# Altium schematic sheet 5V UPS.SchDoc
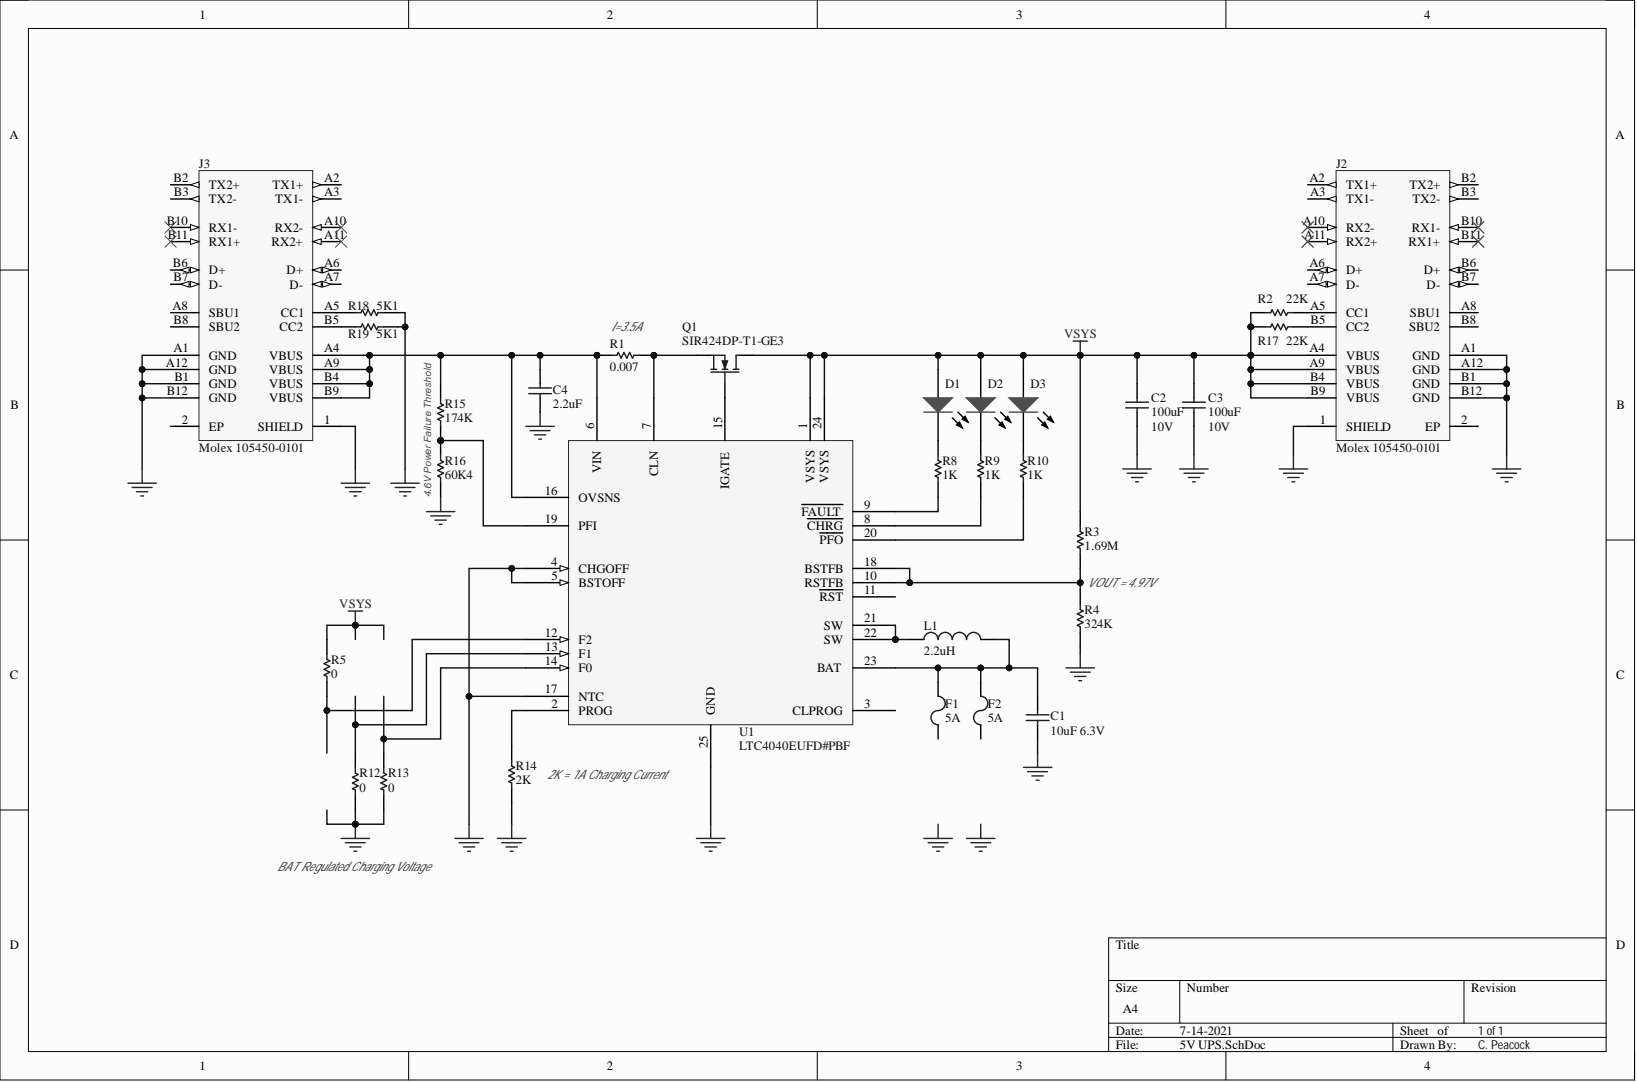
[diagram p0: 5V UPS.SchDoc]

## Parts
C1  10uF 6.3V  [PCB-jc9lkjqzzknv4ogtkgcu-1]
C2  100uF  Voltage Rating (DC): 10V  [CAPC3225X280X60ML40T30]
C3  100uF  Voltage Rating (DC): 10V  [CAPC3225X280X60ML40T30]
C4  2.2uF  [CAPC2013X145X50ML20T25]
D1  Lite-On LTST-C170KRKT  [PCB-5ta9mte2am12bu12jwvi-1]  (value not drawn)
D2  Lite-On LTST-C170KRKT  [PCB-5ta9mte2am12bu12jwvi-1]  (value not drawn)
D3  Lite-On LTST-C170KRKT  [PCB-5ta9mte2am12bu12jwvi-1]  (value not drawn)
F1  5A  [RESC3216X70X50ML20T25]
F2  5A  [RESC3216X70X50ML20T25]
J2  Molex 105450-0101  Manufacturer Part Number: 105450-0101  [PCB-zb4thd4mh8xiiqufiqw2-1]
J3  Molex 105450-0101  Manufacturer Part Number: 105450-0101  [PCB-zb4thd4mh8xiiqufiqw2-1]
L1  2.2uH  [PCB-eh2uh0b1ckxc83nsi4qq-1]
Q1  SIR424DP-T1-GE3  [PCB-12911244-1]
R1  0.007  [PCB-19418829-1]
R2  22K  [RESC2013X60X35ML10T20]
R3  1.69M  [RESC2013X60X35ML10T20]
R4  324K  [RESC2013X60X35ML10T20]
R5  0  [RESC2013X60X35ML10T20]
R8  1K  [RESC2013X60X35ML10T20]
R9  1K  [RESC2013X60X35ML10T20]
R10  1K  [RESC2013X60X35ML10T20]
R12  0  [RESC2013X60X35ML10T20]
R13  0  [RESC2013X60X35ML10T20]
R14  2K  [RESC2013X60X35ML10T20]
R15  174K  [RESC2013X60X35ML10T20]
R16  60K4  [RESC2013X60X35ML10T20]
R17  22K  [RESC2013X60X35ML10T20]
R18  5K1  [RESC2013X60X35ML10T20]
R19  5K1  [RESC2013X60X35ML10T20]
U1  LTC4040EUFD#PBF  [LT-UFD-24_M]

## Nets
GND: C1.1 C2.1 C3.1 C4.1 J2.1(SHIELD) J2.A1(GND) J2.A12(GND) J2.B1(GND) J2.B12(GND) J3.1(SHIELD) J3.A1(GND) J3.A12(GND) J3.B1(GND) J3.B12(GND) R4.2 R12.1 R13.1 R14.1 R16.1 R18.2 R19.2 U1.4(CHGOFF) U1.5(BSTOFF) U1.17(NTC) U1.25(GND)
VSYS: C2.2 C3.2 D1.2 D2.2 D3.2 J2.A4(VBUS) J2.A9(VBUS) J2.B4(VBUS) J2.B9(VBUS) Q1.D R2.1 R3.2 R5.2 R17.1 U1.1(VSYS) U1.24(VSYS)
NetC1_2: C1.2 F1.2 F2.2 L1.2 U1.23(BAT)
NetC4_2: C4.2 J3.A4(VBUS) J3.A9(VBUS) J3.B4(VBUS) J3.B9(VBUS) R1.1 R15.2 U1.6(VIN) U1.16(OVSNS)
NetD1_1: D1.1 R8.2
NetD2_1: D2.1 R9.2
NetD3_1: D3.1 R10.2
NetJ2_A5: J2.A5(CC1) R2.2
NetJ2_B5: J2.B5(CC2) R17.2
NetJ3_A5: J3.A5(CC1) R18.1
NetJ3_B5: J3.B5(CC2) R19.1
NetL1_1: L1.1 U1.21(SW) U1.22(SW)
NetQ1_G: Q1.G U1.15(IGATE)
NetQ1_S: Q1.S R1.2 U1.7(CLN)
NetR3_1: R3.1 R4.1 U1.10(RSTFB) U1.18(BSTFB)
NetR5_1: R5.1 U1.12(F2)
NetR8_1: R8.1 U1.9(FAULT)
NetR9_1: R9.1 U1.8(CHRG)
NetR10_1: R10.1 U1.20(PFO)
NetR12_2: R12.2 U1.13(F1)
NetR13_2: R13.2 U1.14(F0)
NetR14_2: R14.2 U1.2(PROG)
NetR15_1: R15.1 R16.2 U1.19(PFI)

Not connected: F1 1; F2 1; J2 2(EP) A2(TX1+) A3(TX1-) A6(D+) A7(D-) A8(SBU1) A10(RX2-) A11(RX2+) B2(TX2+) B3(TX2-) B6(D+) B7(D-) B8(SBU2) B10(RX1-) B11(RX1+); J3 2(EP) A2(TX1+) A3(TX1-) A6(D+) A7(D-) A8(SBU1) A10(RX2-) A11(RX2+) B2(TX2+) B3(TX2-) B6(D+) B7(D-) B8(SBU2) B10(RX1-) B11(RX1+); U1 3(CLPROG) 11(RST)

## Library data of the parts
C1 = CMP-e7812e33bfa43846-1: Cap Ceramic 10uF 6.3V X7R 10% SMD 0805 125°C Embossed T/R; Manufacturer Part Number: JMK212B7106KG-T; Capacitance: 10u; Capacitance Tolerance: ±10%; Case/Package: 0805, 2012; Component Kind: Standard; Dielectric Characteristic: X7R; Digikey: 587-2396-1-ND; Library Name: CommunityVault; Lifecycle Status: Not Listed by Manufacturer; Mounting Style: Surface Mount; Number of Pins: 2; Octopart URL: http://octopart.com/jmk212b7106kg-t-taiyo+yuden-12090691; OctopartID: e7812e33bfa43846; Packaging: Cut Tape (CT); RoHS: Compliant; Voltage Rating (DC): 6.3 V
C2 C3 = CMP-9010136-2: Samsung Electro-Mechanics 100F 20% 10 V X5R Dielectric SMD Ceramic Multilayer Capacitor 1210; Manufacturer Part Number: CL32A107MPVNNNE; Capacitance: 0.0001 F; Lead-Free Status: Lead Free; Capacitance Tolerance: ±20%; Case/Package: 1210; Component Kind: Standard; Dielectric Characteristic: X5R; Library Name: CommunityVault; Mounting Style: Surface Mount; Octopart Url: https://octopart.com/cl32a107mpvnnne-samsung-24905709; OctopartID: 639fabe94114bc58; RoHS: Compliant
C4 = CMP-7e2cb7ab90b4a1eb-1: 0805 2.2 uF 25 V ±10 % Tolerance X5R SMT Multilayer Ceramic Capacitor; Manufacturer Part Number: CC0805KRX5R8BB225; Capacitance: 2.20 µF; Capacitance Tolerance: ±10%; Lead-Free Status: Lead Free; Voltage Rating (DC): 25.0 V; Case/Package: 0805; Component Kind: Standard; Dielectric Characteristic: X5R; Halogen Free Status: Halogen Free; Library Name: CommunityVault; Mounting Style: Surface Mount; Octopart URL: https://octopart.com/cc0805krx5r8bb225-yageo-9292798; OctopartID: 7e2cb7ab90b4a1eb; Packaging: Tape & Reel (TR); RoHS: Compliant
D1-D3 = CMP-1544870-4: Red 2 x 1.25 x 1.1 mm 130° Water Clear 54 mcd 2 V LED Surface Mount; Case/Package (SI): 2012; Forward Voltage: 2.00 V; Lead-Free Status: Lead Free; Lens Type: Non-Diffused; Luminous Intensity: 54.0 mcd; Wavelength: 639 nm; Case/Package: 0805; Color: Red; Component Kind: Standard; Library Name: CommunityVault; Manufacturer Part Number: LTST-C170KRKT; Mounting Style: Surface Mount, Vertical; Octopart URL: http://octopart.com/ltst-c170krkt-lite-on-549878; OctopartID: 1d24d4265bb4c2e3; Packaging: Cut Tape (CT), Tape & Reel (TR); RoHS: Compliant; Size-Height: 1.10 mm; Size-Length: 2.00 mm; Size-Width: 1.25 mm; Viewing Angle: 130 °
F1 F2 = CMP-475d8ed45800989a-3: Fuse Chip Fast Acting 5A 32V SMD Solder Pad 1206 Ceramic T/R CSA/UL; Manufacturer Part Number: 0437005.WR; Lead-Free Status: Lead Free; Component Kind: Standard; Library Name: CommunityVault; OctopartID: 475d8ed45800989a
J2 J3 = CMP-36bdfbb9c94ee97a-1: USB Shielded I/O Receptacle; Type C; Right Angle; Surface Mount; Gold over Nickel; Gender: Female; Component Kind: Standard; Library Name: CommunityVault; Mounting Style: Surface Mount; Octopart URL: http://octopart.com/105450-0101-molex-68082325; Orientation: Right Angle
L1 = CMP-6312ab38135cbcd5-5: Power inductor, shielded, 20% tol, SMT, RoHS, halogen-f; Manufacturer Part Number: XAL 5030-222MEC; Component Kind: Standard; Library Name: CommunityVault
Q1 = CMP-5ad092fae9868517-5: SiR172DP Series N-Channel 20 V 0.0074 Ohm 41.7 W SMT Mosfet - PowerPAK® SO-8; Component Kind: Standard; Library Name: CommunityVault; Manufacturer Part Number: SIR424DP-T1-GE3
R1 = CMP-19418829-1: RES SMD 0.007 OHM 1% 1W 1632; Manufacturer Part Number: MCS1632R010FER; Catalog Drawings: MCS Series TopMCS Series Footprint; Dynamic Catalog: MCS Series; Featured Product: Metal Element SMD Resistors for Current Sense Requirements; Kits: CAB-SMDMETFL-ND- CABINET ASSORTMENT MCS FCSL; Other Names: MCS1632R010FERCT; Product Training Modules: Current Sensing Resistors; Resistance (Ohms): 0.01; Temperature Coefficient: ±50ppm/°C; Category: Resistors; Ciiva URL: https://ciiva.com/part/mcs1632r010fer-19418829; CiivaID: 19418829; Component Kind: Standard; Composition: Metal Element; Family: Chip Resistor - Surface Mount; Features: Current Sense, Flame Retardant Coating; Height: 0.024" (0.62mm); Library Name: CommunityVault; Number of Terminations: 2; Package / Case: 1206 (3216 Metric); Packaging: Cut Tape (CT); Power (Watts): 1W; Series: MCS; Size / Dimension: 0.126" L x 0.063" W (3.20mm x 1.60mm); Standard Package: 1; Supplier Device Package: 1632; Tolerance: ±1%
R2 R17 = CMP-3ca3f7cba413e364-2: Res Thick Film 0805 22K Ohm 1% 0.125W(1/8W) ±100ppm/C Epoxy Pad SMD T/R; Manufacturer Part Number: RC0805FR-0722KL; Case/Package (SI): 2012; Lead-Free Status: Lead Free; Resistance: 22.0 kΩ; Temperature Coefficient: -100 ppm/°C to 100 ppm/°C; Case/Package: 0805; Component Kind: Standard; Library Name: CommunityVault; Octopart URL: https://octopart.com/rc0805fr-0722kl-yageo-40025558; OctopartID: 3ca3f7cba413e364; Packaging: Tape & Reel; REACH SVHC Compliance: No SVHC; Resistance Tolerance: ±1%; RoHS: Compliant; Size-Height: 500 µm; Size-Length: 2.00 mm; Size-Width: 1.25 mm
R3 = CMP-1866135-2: RES SMD 1.69M OHM 1% 1/8W 0805; Manufacturer Part Number: RC0805FR-071M69L; Case/Package (SI): 2012; Lead-Free Status: Lead Free; Power Rating: 0.125 W; Resistance: 1690000.0 Ω; Voltage Rating (DC): 150.0 V; Case/Package: 0805; Component Kind: Standard; Library Name: CommunityVault; Mounting Style: Surface Mount; Octopart Url: https://octopart.com/rc0805fr-071m69l-yageo-1248466; OctopartID: 9b695270b2dd44ae; Resistance Tolerance: ±1%; RoHS: Compliant; Size-Height: 0.0005 m; Size-Length: 0.002 m; Size-Width: 0.00125 m
R4 = CMP-1875618-2: RES SMD 324K OHM 1% 1/8W 0805; Manufacturer Part Number: RC0805FR-07324KL; Case/Package (SI): 2012; Lead-Free Status: Lead Free; Power Rating: 0.125 W; Resistance: 324000.0 Ω; Voltage Rating (DC): 150.0 V; Case/Package: 0805; Component Kind: Standard; Library Name: CommunityVault; Mounting Style: Surface Mount; Octopart Url: https://octopart.com/rc0805fr-07324kl-yageo-1373753; OctopartID: 23d7eac9f088fc10; Resistance Tolerance: ±1%; RoHS: Compliant; Size-Height: 0.0005 m; Size-Length: 0.002 m; Size-Width: 0.00125 m
R5 R12 R13 = CMP-1875921-8: Res Thick Film 0805 0 Ohm Molded SMD Paper T/R; Manufacturer Part Number: RC0805FR-070RL; Lead-Free Status: Lead Free; Resistance: 0.0 Ω; Resistance Tolerance: ±1%; Case/Package: 0805; Component Kind: Standard; Library Name: CommunityVault; Mounting Style: Surface Mount; Octopart Url: https://octopart.com/rc0805fr-070rl-yageo-9293404; OctopartID: f3d13a5618eb2c16; RoHS: Compliant
R8-R10 = CMP-1854304-19: RC Series 0805 0.125 W 1 kOhms 1% ±100 ppm/°C SMT Thick Film Chip Resistor; Manufacturer Part Number: RC0805FR-071KL; Component Kind: Standard; Library Name: CommunityVault
R14 = CMP-52aa99c2ca168d6d-4: Res Thick Film 0805 2K Ohm 1% 0.125W(1/8W) ±100ppm/C Epoxy Pad SMD T/R; Manufacturer Part Number: RC0805FR-072KL; Case/Package (SI): 2012; Lead-Free Status: Lead Free; Power Rating: 125 mW; Temperature Coefficient: -100 ppm/°C to 100 ppm/°C; Voltage Rating (DC): 150 V; Case/Package: 0805; Component Kind: Standard; Library Name: CommunityVault; Mounting Style: Surface Mount; Number of Pins: 2; Octopart URL: https://octopart.com/rc0805fr-072kl-yageo-40025562; OctopartID: 52aa99c2ca168d6d; Operating Temperature: 155 °C (max); Packaging: Tape & Reel; Resistance Tolerance: ±1%; RoHS: Compliant; Size-Height: 500 µm; Size-Length: 2.00 mm; Size-Width: 1.25 mm
R15 = CMP-1872060-2: RES SMD 174K OHM 1% 1/8W 0805; Manufacturer Part Number: RC0805FR-07174KL; Case/Package (SI): 2012; Lead-Free Status: Lead Free; Power Rating: 0.125 W; Resistance: 174000.0 Ω; Voltage Rating (DC): 150.0 V; Case/Package: 0805; Component Kind: Standard; Library Name: CommunityVault; Mounting Style: Surface Mount; Octopart Url: https://octopart.com/rc0805fr-07174kl-yageo-1224077; OctopartID: 77ecbef4ff07a82b; Resistance Tolerance: ±1%; RoHS: Compliant; Size-Height: 0.0005 m; Size-Length: 0.002 m; Size-Width: 0.00125 m
R16 = CMP-bfd776c8b5e36010-1: RC Series 0805 0.125 W 60.4 kOhms 1% ±100 ppm/°C SMT Thick Film Chip Resistor; Manufacturer Part Number: RC0805FR-0760K4L; Case/Package (SI): 2012; Lead-Free Status: Lead Free; Power Rating: 125 mW; Resistance: 60.4 kΩ; Voltage Rating (DC): 150 V; Case/Package: 0805; Component Kind: Standard; Library Name: CommunityVault; Mounting Style: Surface Mount; Octopart URL: http://octopart.com/rc0805fr-0760k4l-yageo-1388370; OctopartID: bfd776c8b5e36010; Resistance Tolerance: ±1%; RoHS: Compliant; Size-Height: 500 µm; Size-Length: 2.00 mm; Size-Width: 1.25 mm
R18 R19 = CMP-9b27523ffbe444e0-2: Res Thick Film 0805 5.1K Ohm 1% 0.125W(1/8W) ±100ppm/C Epoxy Pad SMD T/R; Component Kind: Standard; Library Name: CommunityVault
U1 = CMP-214aebc9e294fe8d-2: LTC4040 - 2.5A Battery Backup Power Manager; Input Voltage (DC): 5.50 V; Case/Package: QFN; Component Kind: Standard; Library Name: CommunityVault; Manufacturer Part Number: LTC4040EUFD#PBF; Number of Pins: 24; Octopart URL: http://octopart.com/ltc4040eufd%23pbf-linear+technology-59369144; OctopartID: 214aebc9e294fe8d; REACH SVHC Compliance: No SVHC; RoHS: Compliant
